# Altium schematic sheet Power.SchDoc(Power)
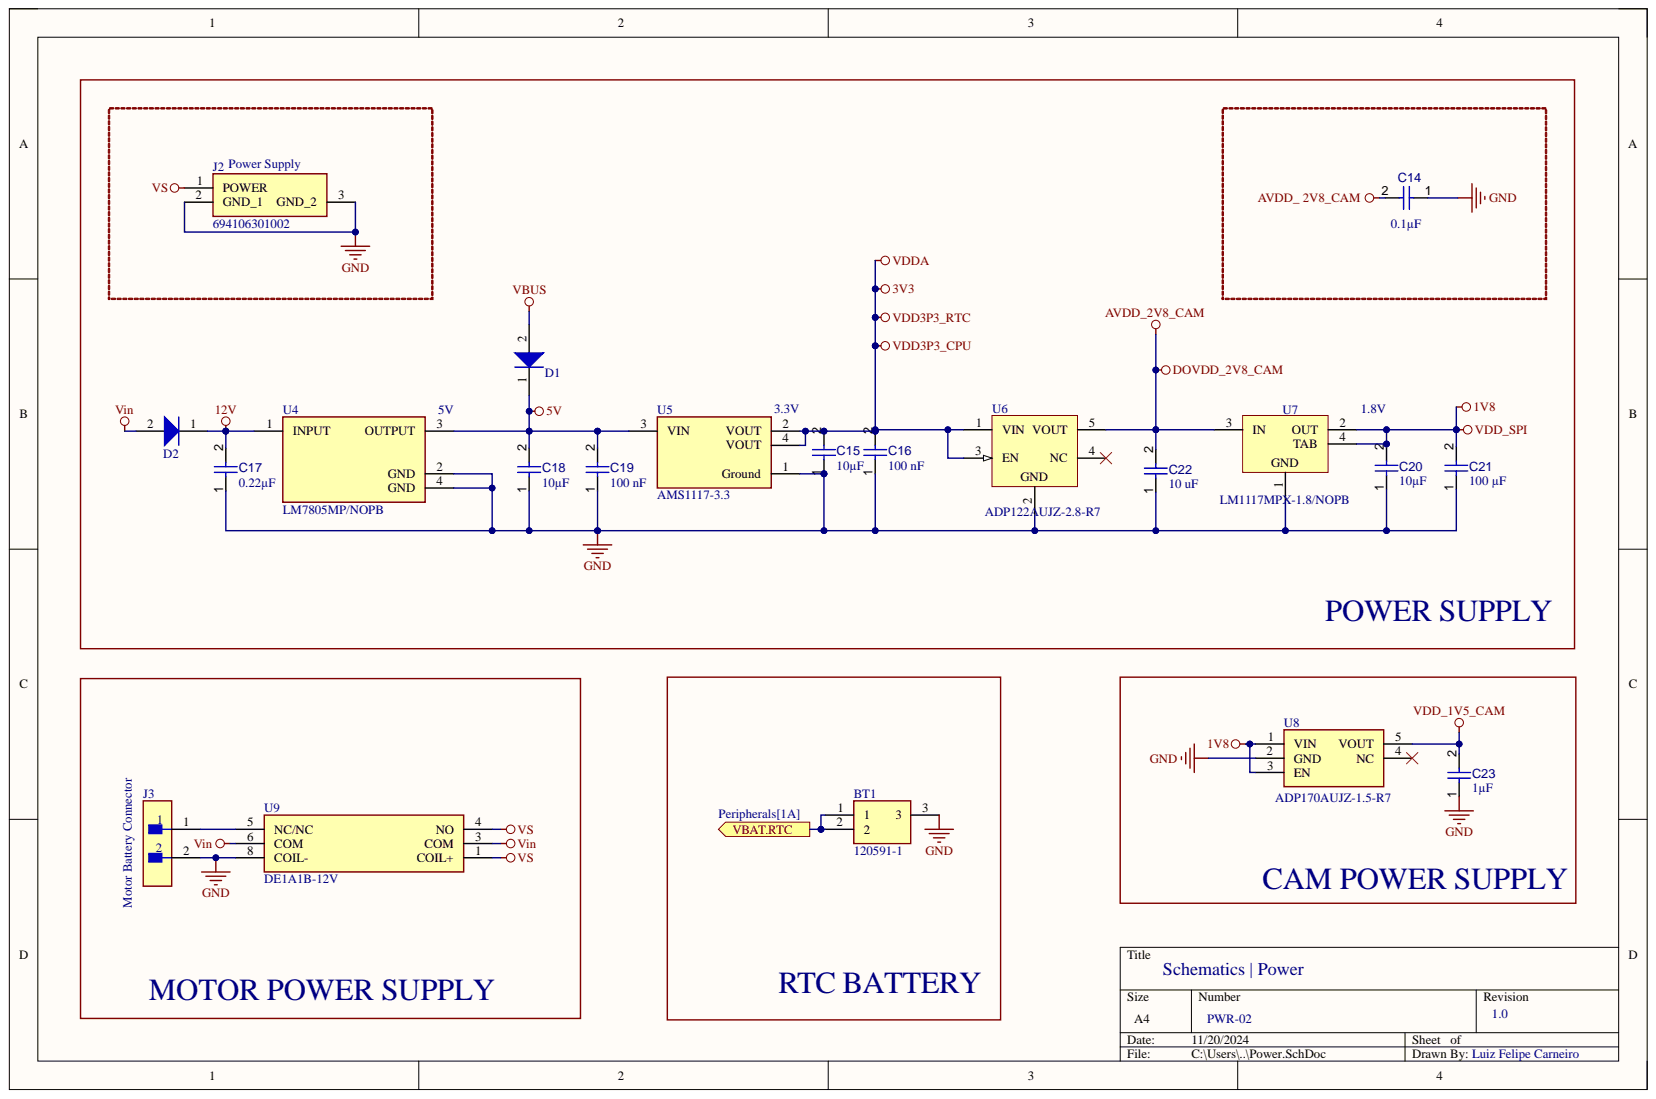
[diagram p0: Power.SchDoc]

## Parts
BT1  120591-1  [120591-1]
C14  value 0.1µF  [CAPC2013X135X45NL10T25]  (hidden comment: Capacitor 10 uF +/- 10% 16 V 0805)
C15  value 10µF  [CAPC2013X135X45NL10T25]  (hidden comment: Capacitor 10 uF +/- 10% 16 V 0805)
C16  value 100 nF  [CAPC1608X06N]  (hidden comment: KAM15AR71E104KT)
C17  value 0.22µF  [CAPC2013X135X45NL10T25]  (hidden comment: Capacitor 10 uF +/- 10% 16 V 0805)
C18  value 10µF  [CAPC2013X135X45NL10T25]  (hidden comment: Capacitor 10 uF +/- 10% 16 V 0805)
C19  value 100 nF  [CAPC1608X06N]  (hidden comment: KAM15AR71E104KT)
C20  value 10µF  [CAPC2013X135X45NL10T25]  (hidden comment: Capacitor 10 uF +/- 10% 16 V 0805)
C21  value 100 µF  [CAPC1608X06N]  (hidden comment: KAM15AR71E104KT)
C22  value 10 uF  [CAPC1608X06N]  (hidden comment: KAM15AR71E104KT)
C23  value 1µF  [CAPC2013X135X45NL10T25]  (hidden comment: Capacitor 10 uF +/- 10% 16 V 0805)
D1  BAT54HT1G  [ONSC-SOD-323-2-477-02_V]  (value not drawn)
D2  BAT54HT1G  [ONSC-SOD-323-2-477-02_V]  (value not drawn)
J2  694106301002  [694106301002_1]
J3  B2B-XH-A(LF)(SN)  [FP-B2B-XH-A_LF_SN-MFG]  (value not drawn)
U4  LM7805MP/NOPB  Output Voltage: 5V  [FP-DCY0004A-MFG]
U5  AMS1117-3.3  Output Voltage: 3.3V  [FP-AMS1117-IPC_C]
U6  ADP122AUJZ-2.8-R7  [ADI-UJ-5_L]
U7  LM1117MPX-1.8/NOPB  [DCY0004A_N]
U8  ADP170AUJZ-1.5-R7  [SOT95P280X100-5N]
U9  DE1A1B-12V  [DE1A12V]

## Ports
VBAT.RTC

## Nets
1V8: C20.2 C21.2 U7.2(OUT) U7.4(TAB) U8.1(VIN) U8.3(EN)
3V3: C15.2 C16.2 U5.2(VOUT) U5.4(VOUT) U6.1(VIN) U6.3(EN)
5V: C18.2 C19.2 D1.1 U4.3(OUTPUT) U5.3(VIN)
12V: C17.2 D2.1 U4.1(INPUT)
AVDD_2V8_CAM: C14.2 C22.2 U6.5(VOUT) U7.3(IN)
GND: BT1.3 C14.1 C15.1 C16.1 C17.1 C18.1 C19.1 C20.1 C21.1 C22.1 C23.1 J2.2 J2.3 J3.2 U4.2(GND) U4.4(GND) U5.1(Ground) U6.2 U7.1 U8.2(GND) U9.8(COIL-)
VBUS: D1.2
VDD_1V5_CAM: C23.2 U8.5(VOUT)
Vin: D2.2 U9.3(COM) U9.6(COM)
VS: J2.1 U9.1(COIL+) U9.4(NO)
VBAT.RTC: BT1.1 BT1.2 ; port VBAT.RTC
NetJ3_1: J3.1 U9.5(NC/NC)

Not connected: U6 4(NC); U8 4(NC)

## Library data of the parts
BT1 = 120591-1: Battery; Manufacturer_Name: TE Connectivity; Arrow Part Number: 120591-1; Arrow Price/Stock: https://www.arrow.com/en/products/120591-1/te-connectivity?utm_currency=USD&region=nac; Component Kind: Standard; Datasheet Link: http://www.te.com/commerce/DocumentDelivery/DDEController?Action=srchrtrv&DocNm=120591&DocType=Customer+Drawing&DocLang=English&DocFormat=pdf&PartCntxt=120591-1; Manufacturer_Part_Number: 120591-1; Mouser Part Number: 571-1205911; Mouser Price/Stock: https://www.mouser.co.uk/ProductDetail/TE-Connectivity/120591-1?qs=cB%252BprIo57OeZ1n1mnEiYEw%3D%3D
C14 C15 C17 C18 C20 C23 = CMP-001-00015-6: Chip Capacitor, 10 uF, +/- 10%, 16 V, 0805 (2012 Metric); Capacitor Type: Ceramic; Voltage Rating: 16V; Case/Package: 0805; Component Kind: Standard; Max Operating Temperature: 85°C; Min Operating Temperature: -55°C; Mounting Technology: SM; Pins: 2; RoHS Compliant: True; Tolerance: 10%; Library Name: HW/FW IoT
C16 C21 C22 = CMP-001-00083-2: Cap Cer 0.1UF 25V X7R 0603; Component Kind: Standard; Library Name: EPTA Workspace
C19 = CMP-001-00083-2: Cap Cer 0.1UF 25V X7R 0603; Value: 100 nF; Component Kind: Standard; Library Name: EPTA Workspace
D1 D2 = CMP-1055-00462-1: Schottky Barrier Diode, 2-Pin SOD-323, Pb-Free, Tape and Reel; Manufacturer: On Semiconductor; Configuration: Single; IFSM Max (A): 0.6; trr Max (ns): 5; Component Kind: Standard; ComponentLink1Description: Manufacturer URL; ComponentLink1URL: http://www.onsemi.com/; ComponentLink2Description: Datasheet; ComponentLink2URL: http://www.onsemi.cn/pub_link/Collateral/BAT54HT1-D.PDF; ComponentLink3Description: Package Specification; ComponentLink3URL: http://www.onsemi.com/pub_link/Collateral/477-02.PDF.1; DatasheetVersion: Rev. 6; Library Name: Altium Content Vault; Mounting Technology: Surface Mount; PackageDescription: 2-Pin SOD, Body 1.7 x 1.25 mm; PackageReference: SOD-323-2-477-02; PackageVersion: Rev. H, 03/2007; Packing: Tape and Reel; PartNumber: BAT54HT1G; RoHS: TRUE
J2 = 694106301002: Connector; Manufacturer_Name: Wurth Elektronik; Component Kind: Standard; Datasheet Link: https://datasheet.datasheetarchive.com/originals/distributors/Datasheets_SAMA/1c153bd2ba42bb51bda59ee4cd9765cd.pdf; Manufacturer_Part_Number: 694106301002; Mouser Part Number: 710-694106301002; Mouser Price/Stock: https://www.mouser.co.uk/ProductDetail/Wurth-Elektronik/694106301002?qs=a9WhcLg8qCwOEkcI62k5mA%3D%3D
J3 = CMP-2000-05888-3: CONN HEADER VERT 2POS 2.5MM; Connector Type: Male Pin; Contact Resistance: 20mR; Current Rating: 3A; Insulation Resistance: 1GR; Max Voltage Rating (AC): 250V; Number of Contacts: 2; Wire/Cable Gauge: 22AWG; Color: Natural; Component Kind: Standard; Contact Material: Brass; Contact Plating: Tin; Contact Type: Male Pin; Depth: 5.75mm; Fastening Type: Detent; Housing Color: White; Housing Material: Polyamide; Lead Free: Lead Free; Lead Pitch: 2.5mm; Length: 7.4mm; Library Name: Altium Content Vault; Max Current Rating: 3A; Max Operating Temperature: 85°C; Max Voltage Rating (DC): 250V; Min Operating Temperature: -25°C; Mount: Through Hole; Number of Positions: 2; Number of Rows: 1; Orientation: Straight; Packaging: Bulk; Pitch: 2.5mm; REACH SVHC: No SVHC; Series: XH; Termination: Solder; Voltage Rating: 250V; Voltage Rating (AC): 250V
U4 = CMP-04917-000007-1: IC REG LINEAR 5V 1.5A SOT223-4; Manufacturer: Texas Instruments; Accuracy Percentage: 3%; Dropout Voltage: 2V; Max Junction Temp: +150°C; Max Supply Voltage: 30V; Min Supply Voltage: 7.5V; Nominal Supply Current: 8mA; Number Of Outputs: 1; Output Type: Fixed; Standoff Height: 0.02mm; Automotive: No; Category: IC; Component Kind: Standard; ComponentLink2Description: Datasheet; ComponentLink2URL: http://www.ti.com/lit/ds/symlink/lm7800.pdf; Device Class L1: Integrated Circuits (ICs); Device Class L2: Power Management ICs; Device Class L3: Voltage Regulators - Linear; Digikey Description: IC REG LINEAR 5V 1.5A SOT223-4; Height: 1.8mm; Lead Free: Yes; Library Name: Altium Content Vault; Manufacturer Part Number: LM7805MP/NOPB; Octopart: https://octopart.com/lm7805mp%2Fnopb-texas+instruments-74629991; Output Current: 1.5A; Package: DCY0004A; RoHS: TRUE; Temperature: 0°C to +125°C; Temperature Range High: +125°C; Temperature Range Low: 0°C
U5 = CMP-209535-000001-1: LDO Voltage Regulators 1A, 3.3V; Manufacturer: Advanced Monolithic Systems; Dropout Voltage: 1.1V; Max Junction Temp: +125°C; Max Power Dissipation: 1.2W; Max Supply Voltage: 15V; Nominal Supply Current: 5mA; Number Of Outputs: 1; Output Type: Fixed; Standoff Height: 0.64mm; Automotive: No; Category: IC; Component Kind: Standard; ComponentLink2Description: Datasheet; ComponentLink2URL: http://www.advanced-monolithic.com/pdf/ds1117.pdf; Device Class L1: Integrated Circuits (ICs); Device Class L2: Power Management ICs; Device Class L3: Voltage Regulators - Linear; Height: 1.8mm; Ipc Land Pattern Name: SOT229P700X180-4; Lead Free: Yes; Library Name: Altium Content Vault; Manufacturer Part Number: AMS1117-3.3; Octopart: https://octopart.com/ams1117-3.3-advanced+monolithic+systems-25899300; Output Current: 1A; Package: AMS1117; RoHS: TRUE; Temperature: -40°C to +125°C; Temperature Range High: +125°C; Temperature Range Low: -40°C
U6 = CMP-0786-00356-1: 300 mA, Low Quiescent Current, CMOS Linear Regulator, 2.3 to 5.5 V Vin, 2.8 V Vout, Industrial, 5-pin SOT23 (UJ-5), Reel; Manufacturer: Analog Devices; Iout max: 300mA; Preset Vout Values (V): 2.8; Supply Current IGND @ Small Load: 45uA; Vin (max) (V): 5.5; Vin (min) (V): 2.3; Code_JEDEC: MO-193-AB; Component Kind: Standard; ComponentLink1Description: Manufacturer URL; ComponentLink1URL: http://www.analog.com/en/index.html; ComponentLink2Description: Datasheet; ComponentLink2URL: http://www.analog.com/static/imported-files/data_sheets/ADP122_123.pdf; ComponentLink3Description: Package Specification; ComponentLink3URL: http:/www.analog.com/static/imported-files/packages/PKG_PDF/TSOT(UJ)/UJ_5.pdf; ComponentLink4Description: URL Link1; ComponentLink4URL: Product URL:http://www.analog.com/en/ADP122/productSearch.html; DatasheetVersion: Rev. E; Library Name: Altium Content Vault; Mounting Technology: Surface Mount; PackageDescription: 5-Pin Thin Small Outline Transistor Package, Body 2.9 x 1.6 mm, Pitch 0.95 mm; PackageReference: UJ-5; PackageVersion: 100708-A, 10/2008; Packing: Reel; PartNumber: ADP122AUJZ-2.8-R7
U7 = CMP-0062-01650-4: 800mA Low-Dropout Linear Regulator, 4-pin SOT-223, Pb-Free; Manufacturer: Texas Instruments; CompType: LDO IC; High-Reliability: Y; PackageTopMarking: XYTT*N12A; PowerWise: N; Code_IPC: SOT223-4; Code_JEDEC: TO-261-AA; Component Kind: Standard; ComponentLink1Description: Datasheet URL; ComponentLink1URL: http://www.ti.com/general/docs/lit/getliterature.tsp?genericPartNumber=LM1117-N&fileType=pdf; Library Name: Altium Content Vault; PackageDescription: Molded SOT-223, 6.50 x 3.56 x 1.6mm Body, 4 Lead, 2.3mm Pitch; PackageReference: DCY0004A; PartNumber: LM1117MPX-1.8/NOPB; PinCount: 4; RoHS: Y
U8 = ADP170AUJZ-1.5-R7: Integrated Circuit; Manufacturer_Name: Analog Devices; Component Kind: Standard; Manufacturer_Part_Number: ADP170AUJZ-1.5-R7
U9 = DE1A-12V: Relay or Contactor; Manufacturer_Name: Panasonic; Component Kind: Standard; Datasheet Link: https://componentsearchengine.com/Datasheets/2/DE1A-12V.pdf; Height: 12.8mm; Manufacturer_Part_Number: DE1A-12V; Mouser Part Number: 769-DE1A-12V
